# Altium schematic sheet PMIC2_iMX6.SchDoc
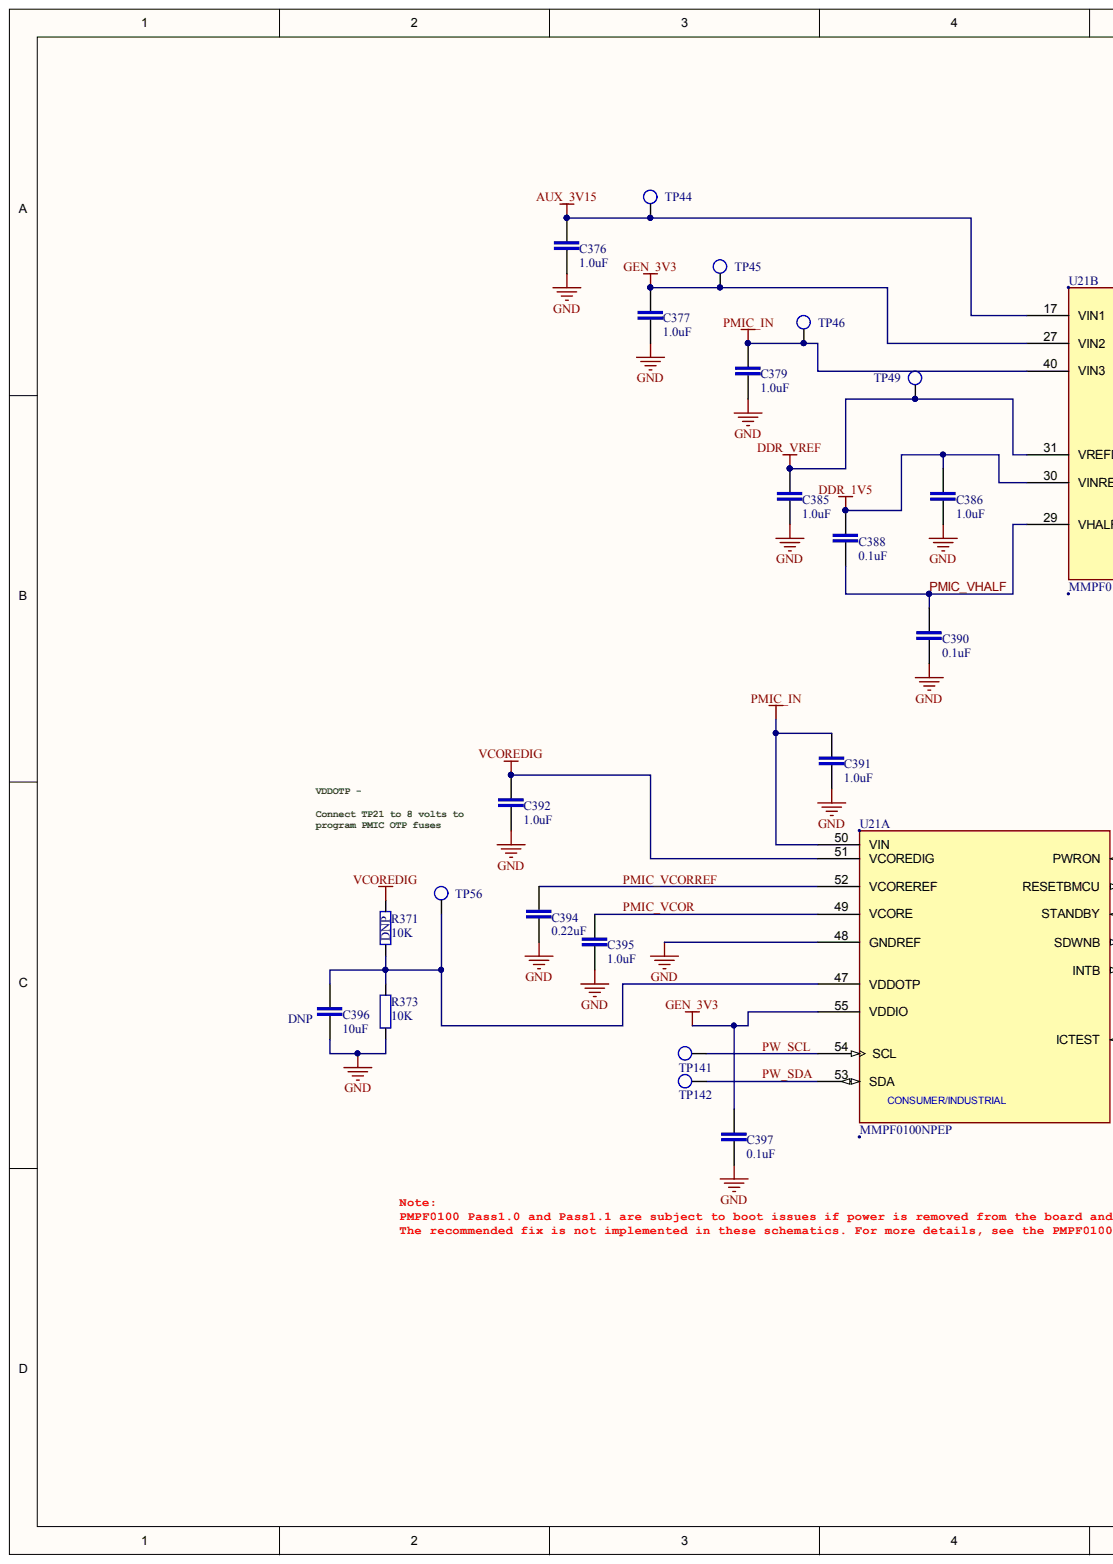
[diagram p0: PMIC2_iMX6.SchDoc, left]
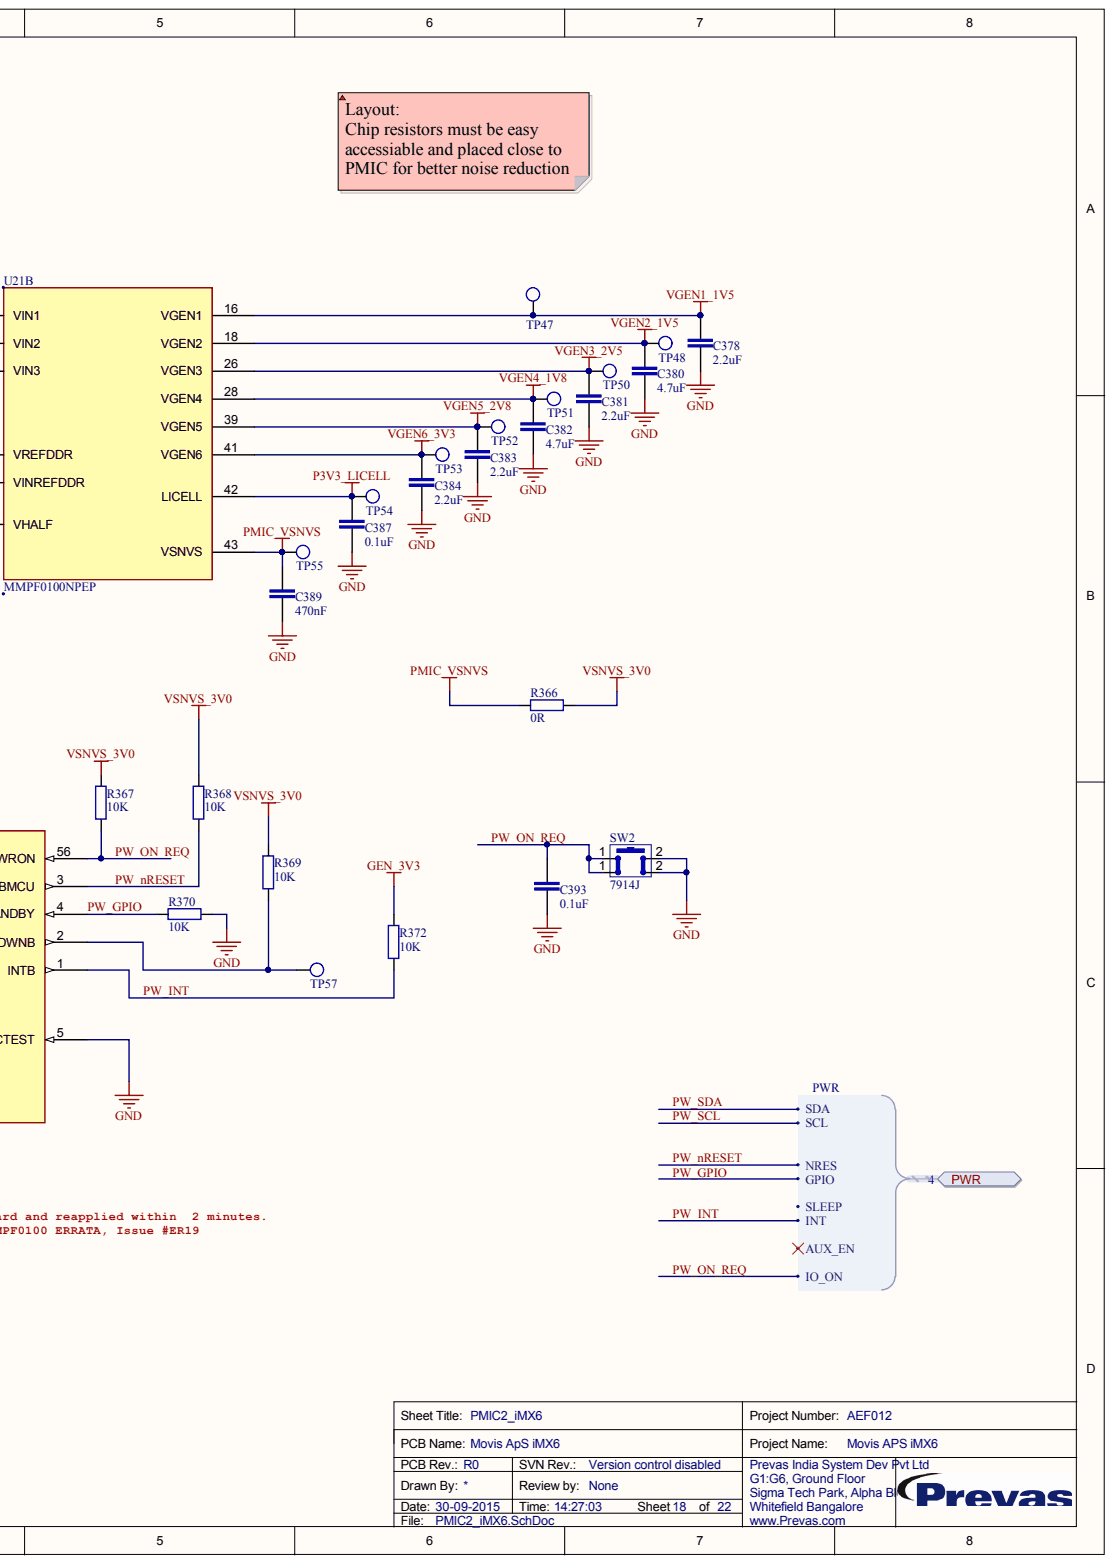
[diagram p1: PMIC2_iMX6.SchDoc, right]

## Parts
C376  1.0uF  [CAPC1608X90N]
C377  1.0uF  [CAPC1608X90N]
C378  2.2uF
C379  1.0uF  [CAPC1608X90N]
C380  4.7uF  [CAPC1608X90N]
C381  2.2uF
C382  4.7uF  [CAPC1608X90N]
C383  2.2uF
C384  2.2uF
C385  1.0uF  [CAPC1608X90N]
C386  1.0uF  [CAPC1608X90N]
C387  0.1uF  [CAPC1005X55N]
C388  0.1uF  [CAPC1005X55N]
C389  470nF  [CAPC1005X55N]
C390  0.1uF  [CAPC1005X55N]
C391  1.0uF  [CAPC1608X90N]
C392  1.0uF  [CAPC1608X90N]
C393  0.1uF  [CAPC1005X55N]
C394  0.22uF  [CAPC1005X55N]
C395  1.0uF  [CAPC1608X90N]
C396  10uF  Fitted: DNP  [CAPC1608X95N]
C397  0.1uF  [CAPC1005X55N]
R366  0R  [RESC1608X55N]
R367  10K  [RESC1608X55N]
R368  10K  [RESC1608X55N]
R369  10K  [RESC1608X55N]
R370  10K  [RESC1608X55N]
R371  10K  Fitted: DNP  [RESC1608X55N]
R372  10K  [RESC1608X55N]
R373  10K  [RESC1608X55N]
SW2  7914J  [SW_7914J]
TP44  Testpoint  [TP_SMD]  (value not drawn)  (no BOM)
TP45  Testpoint  [TP_SMD]  (value not drawn)  (no BOM)
TP46  Testpoint  [TP_SMD]  (value not drawn)  (no BOM)
TP47  Testpoint  [TP_SMD]  (value not drawn)  (no BOM)
TP48  Testpoint  [TP_SMD]  (value not drawn)  (no BOM)
TP49  Testpoint  [TP_SMD]  (value not drawn)  (no BOM)
TP50  Testpoint  [TP_SMD]  (value not drawn)  (no BOM)
TP51  Testpoint  [TP_SMD]  (value not drawn)  (no BOM)
TP52  Testpoint  [TP_SMD]  (value not drawn)  (no BOM)
TP53  Testpoint  [TP_SMD]  (value not drawn)  (no BOM)
TP54  Testpoint  [TP_SMD]  (value not drawn)  (no BOM)
TP55  Testpoint  [TP_SMD]  (value not drawn)  (no BOM)
TP56  Testpoint  [TP_SMD]  (value not drawn)  (no BOM)
TP57  Testpoint  [TP_SMD]  (value not drawn)  (no BOM)
TP141  Testpoint  [TP_SMD]  (value not drawn)  (no BOM)
TP142  Testpoint  [TP_SMD]  (value not drawn)  (no BOM)
U21  MMPF0100NPEP  [QFN50P800X800X90-57N]  (parts A,B)

## Ports
PWR, PWR.AUX_EN, PWR.GPIO, PWR.INT, PWR.IO_ON, PWR.NRES, PWR.SCL, PWR.SDA, PWR.SLEEP

## Nets
AUX_3V15: C376.2 TP44.1 U21.17(VIN1)
DDR_1V5: C386.2 C388.2 U21.30(VINREFDDR)
DDR_VREF: C385.2 TP49.1 U21.31(VREFDDR)
GEN_3V3: C377.2 C397.2 R372.2 TP45.1 U21.27(VIN2) U21.55(VDDIO)
GND: C376.1 C377.1 C378.1 C379.1 C380.1 C381.1 C382.1 C383.1 C384.1 C385.1 C386.1 C387.1 C389.1 C390.1 C391.2 C392.1 C393.1 C394.1 C395.1 C396.1 C397.1 R370.1 R373.1 SW2.2 U21.5(ICTEST) U21.48(GNDREF)
P3V3_LICELL: C387.2 TP54.1 U21.42(LICELL)
PMIC_IN: C379.2 C391.1 TP46.1 U21.40(VIN3) U21.50(VIN)
PMIC_VSNVS: C389.2 R366.2 TP55.1 U21.43(VSNVS)
VCOREDIG: C392.2 R371.2 U21.51(VCOREDIG)
VGEN1_1V5: C378.2 TP47.1 U21.16(VGEN1)
VGEN2_1V5: C380.2 TP48.1 U21.18(VGEN2)
VGEN3_2V5: C381.2 TP50.1 U21.26(VGEN3)
VGEN4_1V8: C382.2 TP51.1 U21.28(VGEN4)
VGEN5_2V8: C383.2 TP52.1 U21.39(VGEN5)
VGEN6_3V3: C384.2 TP53.1 U21.41(VGEN6)
VSNVS_3V0: R366.1 R367.2 R368.2 R369.2
PMIC_VCOR: C395.2 U21.49(VCORE)
PMIC_VCORREF: C394.2 U21.52(VCOREREF)
PMIC_VHALF: C388.1 C390.2 U21.29(VHALF)
PW_GPIO: R370.2 U21.4(STANDBY) ; port PWR
PW_INT: R372.1 U21.1(INTB) ; port PWR
PW_NRESET: R368.1 U21.3(RESETBMCU) ; port PWR
PW_ON_REQ: C393.2 R367.1 SW2.1 U21.56(PWRON) ; port PWR
PW_SCL: TP141.1 U21.54(SCL) ; port PWR
PW_SDA: TP142.1 U21.53(SDA) ; port PWR
NetC396_2: C396.2 R371.1 R373.2 TP56.1 U21.47(VDDOTP)
NetR369_1: R369.1 TP57.1 U21.2(SDWNB)
N01232: ; port PWR
N01233: ; port PWR

## Library data of the parts
C376 C377 C379 C385 C386 C391 C392 C395 = INNISI-79: CAP CER 1UF 16V 10% X7R 0603; ref_part_type: /capacitor/non_polarized/ceramic/; source_manufacturer_1: Murata; source_manufacturer_1_pn: GRM188R71C105KE15D; cae_altium_pcb_status: Prototype; Database Table Name: altium_default; db_rev: 7576; elec_temp_range: -55 to +125; phy_height: 0.90mm; phy_mounting: SMD; phy_packaging_type: 0603 (1608 Metric); ref_datasheet: INNISI-33; ref_keyword: Ceramic Capacitor 0603; cae_altium_sch_status: Prototype; Component Kind: Standard; ComponentLink1Description: Datasheet; ComponentLink1URL: https://cop201.prevas.dk/viewvc/datasheet/INNISI-33.pdf?root=hw_components&view=co; db_created: 2015-08-03 05:39:06.0; db_last_updated: 03-08-2015 05:39:08; db_local_id: INNISI-79; db_status: Prototype; elec_tolerance: 10%; elec_value: 1.0uF; elec_voltage_rating: 16V; phy_material: X7R; Library Name: altium_mysql.dblib
C378 C381 C383 C384 = HKDS-75: Ceramic capacitor X5R 0603; ref_part_type: /capacitor/non_polarized/ceramic/; source_manufacturer_1: Yageo; source_manufacturer_1_pn: CC0603KRX5R6BB225; cae_altium_sch_status: Prototype; Database Table Name: altium_default; db_rev: 6782; elec_temp_range: -55 to +85C; elec_tolerance: 10%; elec_voltage_rating: 10V; phy_height: 0.8mm; phy_mounting: SMD; phy_packaging_type: 0603; ref_datasheet: HKDS-142; ref_keyword: Multilayer chip capacitor; Component Kind: Standard; ComponentLink1Description: Datasheet; ComponentLink1URL: https://cop201.prevas.dk/viewvc/datasheet/HKDS-142.pdf?root=hw_components&view=co; db_created: 2014-09-05 09:06:36.0; db_last_updated: 05-09-2014 09:07:36; db_local_id: HKDS-75; db_status: Prototype; elec_value: 2.2uF; phy_material: X5R; Library Name: altium_mysql.dblib
C380 C382 = INNISI-102: Ceramic capacitor X5R 0603; ref_part_type: /capacitor/non_polarized/ceramic/; source_manufacturer_1: TAIYO YUDEN; source_manufacturer_1_pn: EMK107ABJ475KA-T; cae_altium_pcb_status: Prototype; Database Table Name: altium_default; db_rev: 7663; elec_temp_range: -55 to +85; elec_tolerance: 10%; elec_voltage_rating: 16V; phy_height: 0.90mm; phy_mounting: SMD; phy_packaging_type: 0603; ref_keyword: Multilayer chip capacitor; cae_altium_sch_status: Prototype; Component Kind: Standard; ComponentLink1Description: Datasheet; db_created: 2015-08-04 09:58:51.0; db_last_updated: 04-08-2015 09:58:53; db_local_id: INNISI-102; db_status: Prototype; elec_value: 4.7uF; phy_material: X5R; Library Name: altium_mysql.dblib
C387 C388 C390 C393 C397 = INNISI-73: CAP CER 0.1UF 50V 10% X5R 0402; ref_part_type: /capacitor/non_polarized/ceramic/; source_manufacturer_1: TDK Corporation; source_manufacturer_1_pn: C1005X5R1H104K050BB; cae_altium_pcb_status: Prototype; Database Table Name: altium_default; db_rev: 7569; elec_temp_range: -55 to +85 C; phy_height: 0.55mm; phy_mounting: SMD; phy_packaging_type: 0402; ref_datasheet: INNISI-71; cae_altium_sch_status: Prototype; Component Kind: Standard; ComponentLink1Description: Datasheet; ComponentLink1URL: https://cop201.prevas.dk/viewvc/datasheet/INNISI-71.pdf?root=hw_components&view=co; db_created: 2015-08-03 03:34:59.0; db_last_updated: 03-08-2015 03:35:01; db_local_id: INNISI-73; db_status: Prototype; elec_tolerance: ±10%; elec_value: 0.1uF; elec_voltage_rating: 50V; phy_material: X5R; ref_keyword: CAP CER 0.1UF 50V 10% X5R 0402; Library Name: altium_mysql.dblib
C389 = INNISI-87: CAP CER470nF 50V 10% X5R 0402; ref_part_type: /capacitor/non_polarized/ceramic/; source_manufacturer_1: Murata; source_manufacturer_1_pn: UMK105ABJ474KV-F; cae_altium_pcb_status: Prototype; Database Table Name: altium_default; db_rev: 7594; elec_temp_range: -55 to +125C; phy_height: 0.55mm; phy_mounting: SMD; phy_packaging_type: 0402; ref_datasheet: INNISI-79; ref_keyword: Ceramic capacitor X7R 0402; cae_altium_sch_status: Prototype; Component Kind: Standard; ComponentLink1Description: Datasheet; ComponentLink1URL: https://cop201.prevas.dk/viewvc/datasheet/INNISI-79.pdf?root=hw_components&view=co; db_created: 2015-08-03 06:49:53.0; db_last_updated: 03-08-2015 06:49:55; db_local_id: INNISI-87; db_status: Prototype; elec_tolerance: 10%; elec_value: 470nF; elec_voltage_rating: 50V; phy_material: X5R; Library Name: altium_mysql.dblib
C394 = innisi-76: CAP CER 0.22UF 16V 10% X7R 0402; ref_part_type: /capacitor/non_polarized/ceramic/; source_manufacturer_1: Murata; source_manufacturer_1_pn: GRM155R71C224KA12D; cae_altium_pcb_status: Prototype; Database Table Name: altium_default; db_rev: 7572; elec_temp_range: -55 to +125C; phy_height: 0.55mm; phy_mounting: SMD; phy_packaging_type: 0402; ref_datasheet: INNISI-73; ref_keyword: Ceramic capacitor X7R 0402; cae_altium_sch_status: Prototype; Component Kind: Standard; ComponentLink1Description: Datasheet; ComponentLink1URL: https://cop201.prevas.dk/viewvc/datasheet/INNISI-73.pdf?root=hw_components&view=co; db_created: 2015-08-03 04:28:56.0; db_last_updated: 03-08-2015 04:28:58; db_local_id: INNISI-76; db_status: Prototype; elec_tolerance: 10%; elec_value: 0.22uF; elec_voltage_rating: 16V; phy_material: X7R; Library Name: altium_mysql.dblib
C396 = innisi-78: CAP CER 10UF 16V 10% X5R 0603; ref_part_type: /capacitor/non_polarized/ceramic/; source_manufacturer_1: Murata; source_manufacturer_1_pn: GRM188R61C106KAALD; cae_altium_pcb_status: Prototype; Database Table Name: altium_default; db_rev: 7574; elec_temp_range: -55 to +85C; phy_height: 0.95mm; phy_mounting: SMD; phy_packaging_type: 0603; ref_datasheet: INNISI-75; ref_keyword: Ceramic capacitor X5R 0603; cae_altium_sch_status: Prototype; Component Kind: Standard; ComponentLink1Description: Datasheet; ComponentLink1URL: https://cop201.prevas.dk/viewvc/datasheet/INNISI-75.pdf?root=hw_components&view=co; db_created: 2015-08-03 05:08:42.0; db_last_updated: 03-08-2015 05:08:44; db_local_id: INNISI-78; db_status: Prototype; elec_tolerance: 10%; elec_value: 10uF; elec_voltage_rating: 16V; phy_material: X5R; Library Name: altium_mysql.dblib
R366 = INVIHA-4: RES SMD 0.0 OHM JUMPER 1/10W; ref_part_type: /resistor/fixed/; source_manufacturer_1: Panasonic Electronic Components; source_manufacturer_1_pn: ERJ-3GEY0R00V; cae_altium_pcb_status: Prototype; Database Table Name: altium_default; db_rev: 7580; elec_power_rating: 0.1W; elec_temp_range: -55 to +155° C; phy_height: 0.55mm; phy_packaging_type: 0603; ref_datasheet: INVIHA-3; ref_keyword: Chip Resistor; cae_altium_sch_status: Prototype; Component Kind: Standard; ComponentLink1Description: Datasheet; ComponentLink1URL: https://cop201.prevas.dk/viewvc/datasheet/INVIHA-3.pdf?root=hw_components&view=co; db_created: 2015-08-03 05:59:19.0; db_last_updated: 03-08-2015 5:59:21 AM; db_local_id: INVIHA-4; db_status: Prototype; elec_value: 0R; phy_mounting: SMD; Library Name: altium_mysql.dblib
R367-R373 = INVIHA-3: RES SMD 10K OHM 1% 1/10W 0603; ref_part_type: /resistor/fixed/; source_manufacturer_1: Yageo; source_manufacturer_1_pn: RC0603FR-0710KL; cae_altium_pcb_status: Prototype; Database Table Name: altium_default; db_rev: 7575; elec_power_rating: 0.1W; elec_temp_range: -55 to +155° C; phy_height: 0.55mm; phy_packaging_type: 0603; ref_datasheet: INVIHA-2; ref_keyword: Chip Resistor; cae_altium_sch_status: Prototype; Component Kind: Standard; ComponentLink1Description: Datasheet; ComponentLink1URL: https://cop201.prevas.dk/viewvc/datasheet/INVIHA-2.pdf?root=hw_components&view=co; db_created: 2015-08-03 05:39:03.0; db_last_updated: 03-08-2015 5:39:05 AM; db_local_id: INVIHA-3; db_status: Prototype; elec_tolerance: 1%; elec_value: 10K; phy_mounting: SMD; Library Name: altium_mysql.dblib
SW2 = IBAT-15: Tactile Switch (Push Button); ref_part_type: /elmech/transducer/switch/; source_manufacturer_1: BOURNS; source_manufacturer_1_pn: BOURNS - 7914J-001-000; cae_altium_pcb_status: Prototype; Database Table Name: altium_default; db_rev: 574; elec_current_rating: 100mA; elec_temp_range: -55 to 125; elec_voltage_rating: 16V; phy_height: 4mm; phy_mounting: SMD; phy_packaging_type: Special; ref_datasheet: IBAT-13; ref_keyword: 7914 4 mm SMD; cae_altium_sch_status: Prototype; Component Kind: Standard; ComponentLink1Description: Datasheet; ComponentLink1URL: https://cop201.prevas.dk/viewvc/datasheet/IBAT-13.pdf?root=hw_components&view=co; db_created: 2008-11-03 11:39:16; db_last_updated: 18-11-2008 15:59:33; db_local_id: IBAT-15; db_status: Prototype; elec_value: 7914J; Library Name: altium_mysql.dblib
TP44-TP57 TP141 TP142 = JEPE-114: Test Point SMD; ref_part_type: /pcb_item/; cae_altium_pcb_status: Prototype; Database Table Name: altium_default; db_rev: 3281; cae_altium_sch_status: Prototype; ComponentLink1Description: Datasheet; db_created: 2008-08-18 11:39:42; db_last_updated: 23-03-2011 14:14:06; db_local_id: JEPE-114; db_status: Prototype; elec_value: Test Point; phy_mounting: SMD; ref_keyword: Test  Point; Library Name: altium_mysql.dblib
U21 = INRAAN-3: 14 Channel Configurable Power Management IC; ref_part_type: /integrated/analog/power/supply/distribution/; source_manufacturer_1: Freescale; AGILE_PN: 315-79407; CAD_APPROVED: Yes; cae_altium_pcb_status: Prototype; Database Table Name: altium_default; db_rev: 6250; elec_current_rating: 0 to 10mA; elec_frequency_rating: 4MHz; elec_temp_range: -40 to 125; elec_value: PF0100; elec_voltage_rating: 2.8 to 4.5V; MFG_NAME01: FREESCALE SEMICONDUCTOR; phy_height: 0.9; phy_mounting: SMD; phy_packaging_type: QFN56; ref_keyword: PMIC; SPLIT_INST: TRUE; SWAP_INFO: (S1+S2+S3); cae_altium_sch_status: Prototype; CLASS: IC; Component Kind: Standard; ComponentLink1Description: Datasheet; ComponentLink1URL: https://cop201.prevas.dk/viewvc/datasheet/INRAAN-3.pdf?root=hw_components&view=co; db_created: 2013-10-21 10:26:23.0; db_last_updated: 27-12-2013 12:12:58; db_local_id: INRAAN-3; db_status: Prototype; HEIGHT: 0.9MM; MFG_PN01: MMPF0100NPEP; ref_datasheet: INRAAN-3; ROHS: Yes; source_manufacturer_1_pn: MMPF0100NPEP; Library Name: altium_mysql.dblib
